# Altium schematic sheet ROBOSOM_V2R1.SchDoc
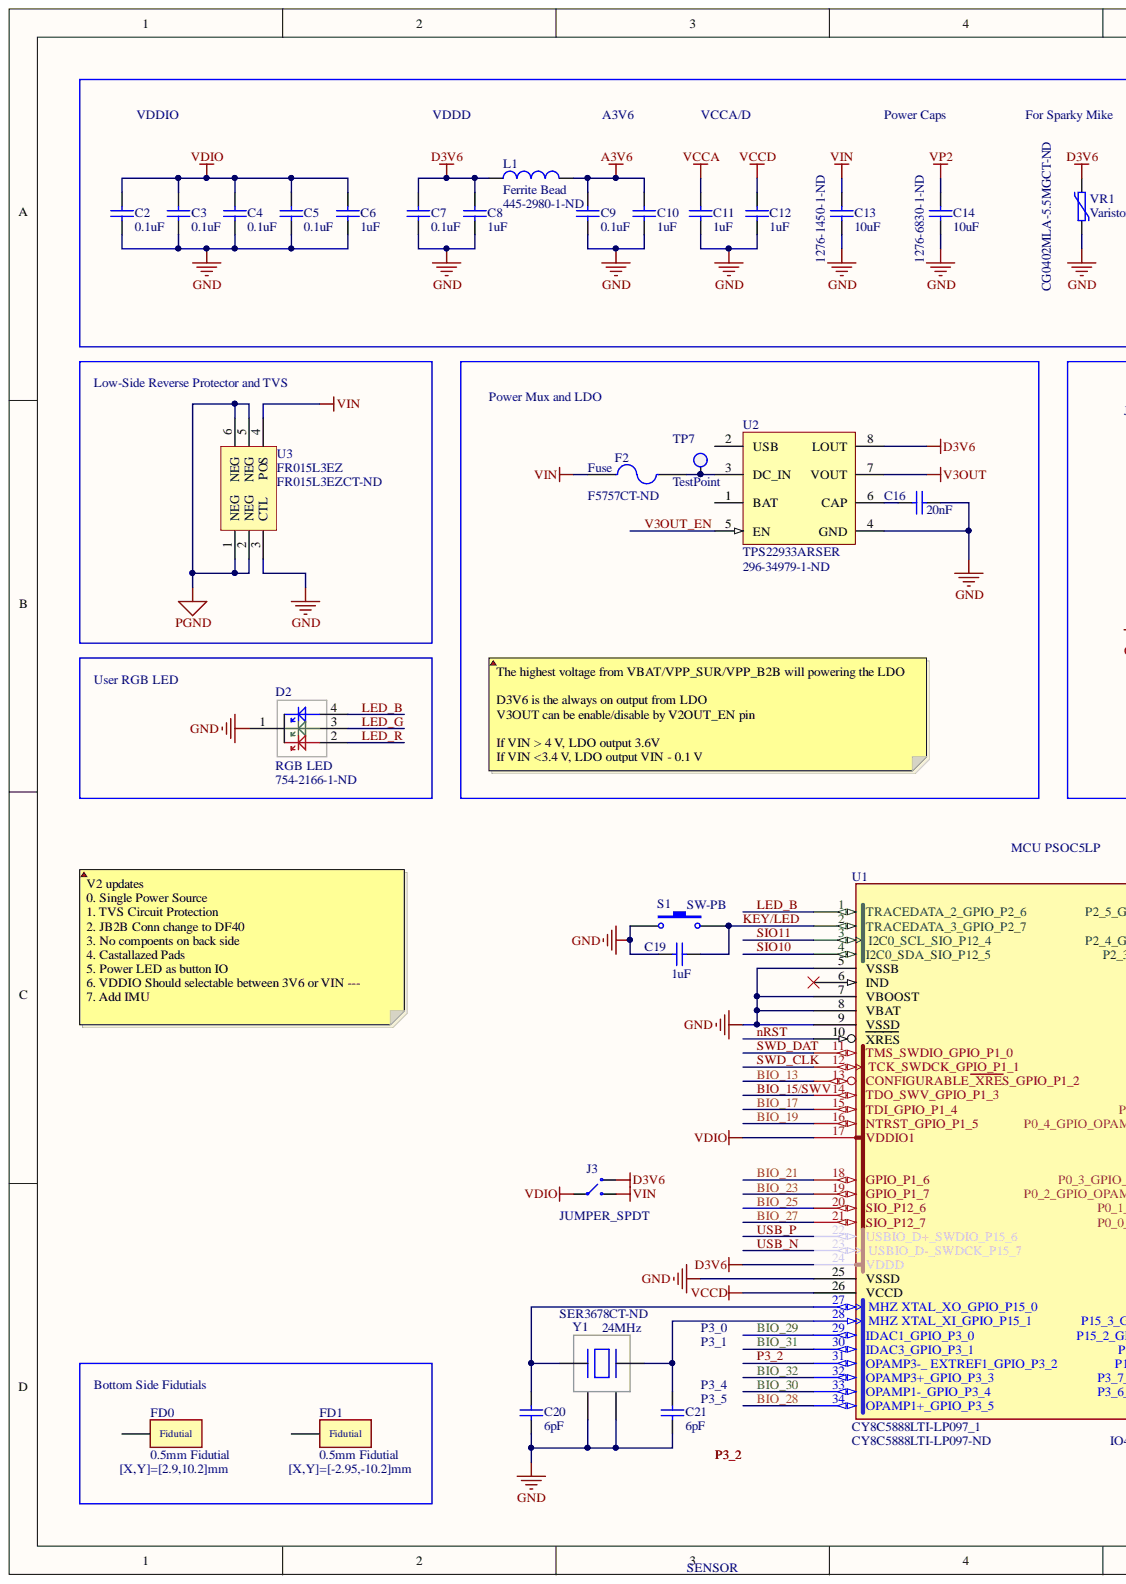
[diagram p0: ROBOSOM_V2R1.SchDoc, left]
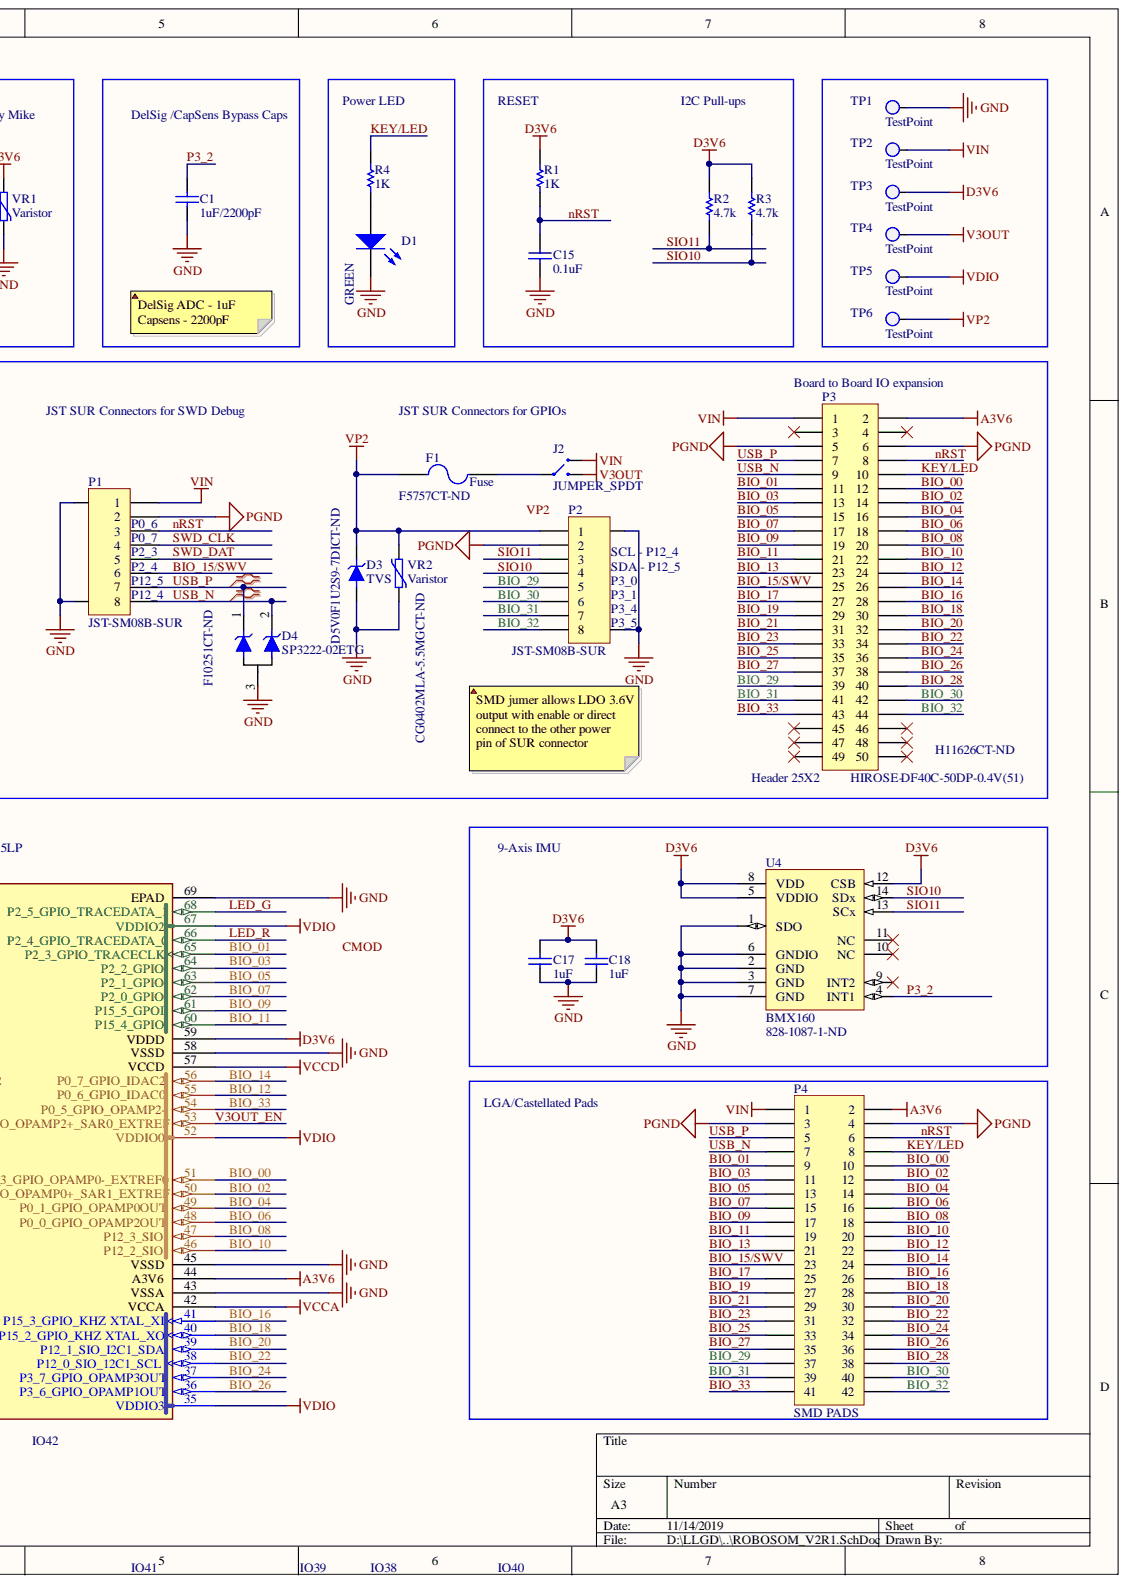
[diagram p1: ROBOSOM_V2R1.SchDoc, right]

## Parts
C1  Cap  value 1uF/2200pF  [C0201]
C2  value 0.1uF  [C0201]  (hidden comment: Cap)
C3  value 0.1uF  [C0201]  (hidden comment: Cap)
C4  value 0.1uF  [C0201]  (hidden comment: Cap)
C5  value 0.1uF  [C0201]  (hidden comment: Cap)
C6  value 1uF  [C0201]  (hidden comment: Cap)
C7  value 0.1uF  [C0201]  (hidden comment: Cap)
C8  value 1uF  [C0201]  (hidden comment: Cap)
C9  value 0.1uF  [C0201]  (hidden comment: Cap)
C10  value 1uF  [C0201]  (hidden comment: Cap)
C11  value 1uF  [C0201]  (hidden comment: Cap)
C12  value 1uF  [C0201]  (hidden comment: Cap)
C13  value 10uF  Capacitance: 10uF  Supplier Part Number 1: 1276-1450-1-ND  [C0402]  (hidden comment: Cap)
C14  Cap  value 10uF  Capacitance: 10uF  Supplier Part Number 2: 1276-6830-1-ND  [C0402]
C15  value 0.1uF  [C0201]  (hidden comment: Cap)
C16  value 20nF  [C0201]  (hidden comment: Cap)
C17  value 1uF  [C0201]  (hidden comment: Cap)
C18  value 1uF  [C0201]  (hidden comment: Cap)
C19  value 1uF  [C0201]  (hidden comment: Cap)
C20  value 6pF  [C0201]  (hidden comment: Cap)
C21  value 6pF  [C0201]  (hidden comment: Cap)
D1  GREEN  [D0402]
D2  RGB LED  Supplier Part Number 1: 754-2166-1-ND  [LED_RGB_0606_APTF1616SEJ3ZGGVBDC]
D3  TVS  Supplier Part Number 1: D5V0F1U2S9-7DICT-ND  [SOD-923]
D4  SP3222-02ETG  Supplier Part Number 1: F10251CT-ND  [UFDFN3-SP3222-02ETG]
F1  Fuse  Supplier Part Number 1: F5757CT-ND  [R0402]
F2  Fuse  Supplier Part Number 1: F5757CT-ND  [R0402]
FD0  0.5mm Fidutial  Location: [X,Y]=[2.9,10.2]mm  [Fiducial_0.5MM - S]
FD1  0.5mm Fidutial  Location: [X,Y]=[-2.95,-10.2]mm  [Fiducial_0.5MM - S]
J2  JUMPER_SPDT  [JUMPER_SPDT_0402_MINI_EN]
J3  JUMPER_SPDT  [JUMPER_SPDT_0402_MINI_EN]
L1  Ferrite Bead  [R0402]
P1  JST-SM08B-SUR  [SM08B-SURS-TF]
P2  JST-SM08B-SUR  [SM08B-SURS-TF]
P3  Header 25X2  Supplier Part Number 1: H11626CT-ND  [CON-HIROSE-DF40C-50DP-0.4V(51)]
P4  SMD PADS  [PSOC5_SOM_V2_SMD]
R1  value 1K  [R0201]  (hidden comment: Res1)
R2  value 4.7k  [R0201]  (hidden comment: Res1)
R3  value 4.7k  [R0201]  (hidden comment: Res1)
R4  value 1K  [R0201]  (hidden comment: Res1)
S1  SW-PB  [SW-PB-EVPBB]
TP1  TestPoint  [TP_0.75MM_RECT_SMD]
TP2  TestPoint  [TP_0.75MM_CIRL_SMD]
TP3  TestPoint  [TP_0.75MM_CIRL_SMD]
TP4  TestPoint  [TP_0.75MM_CIRL_SMD]
TP5  TestPoint  [TP_0.75MM_CIRL_SMD]
TP6  TestPoint  [TP_0.75MM_CIRL_SMD]
TP7  TestPoint  [TP_0.5mm_SMD]
U1  CY8C5888LTI-LP097_1  Supplier Part Number 1: CY8C5888LTI-LP097-ND  [QFN40P800X800X100-69N-PSOC5LP]
U2  TPS22933ARSER  Supplier Part Number 1: 296-34979-1-ND  [UFQFN-8-TPS22933]
U3  FR015L3EZ  Supplier Part Number 1: FR015L3EZCT-ND  [WDFN6-FR015L3EZ]
U4  BMX160  Supplier Part Number 1: 828-1087-1-ND  [VFLGA14-BMX160]
VR1  Varistor  Supplier Part Number 1: CG0402MLA-5.5MGCT-ND  [R0201]
VR2  Varistor  Supplier Part Number 1: CG0402MLA-5.5MGCT-ND  [R0201]
Y1  24MHz  Supplier Part Number 1: SER3678CT-ND  [CY-EPSON-FA-128-S]

## Nets
A3V6: C9.1 C10.1 L1.2 P3.2 P4.2 U1.44(A3V6)
D3V6: C7.1 C8.1 C17.2 C18.2 J3.1 L1.1 R1.1 R2.1 R3.1 TP3.1 U1.24(VDDD) U1.59(VDDD) U2.8(LOUT) U4.5(VDDIO) U4.8(VDD) U4.12(CSB) VR1.2
GND: C1.1 C2.2 C3.2 C4.2 C5.2 C6.2 C7.2 C8.2 C9.2 C10.2 C11.2 C12.2 C13.2 C14.2 C15.2 C16.2 C17.1 C18.1 C19.1 C20.2 C21.2 D1.2 D2.1 D3.A D4.3 P1.0 P2.0 S1.2 TP1.1 U1.5(VSSB) U1.7(VBOOST) U1.8(VBAT) U1.9(VSSD) U1.25(VSSD) U1.43(VSSA) U1.45(VSSD) U1.58(VSSD) U1.69(EPAD) U2.4(GND) U3.3(CTL) U4.1(SDO) U4.2(GND) U4.3(GND) U4.6(GNDIO) U4.7(GND) VR1.1 VR2.1 Y1.2 Y1.4
PGND: P1.2 P2.2 P3.5 P3.6 P4.3 P4.4 U3.1(NEG) U3.2(NEG) U3.5(NEG) U3.6(NEG)
V3OUT: J2.3 TP4.1 U2.7(VOUT)
VCCA: C11.1 U1.42(VCCA)
VCCD: C12.1 U1.26(VCCD) U1.57(VCCD)
VDIO: C2.1 C3.1 C4.1 C5.1 C6.1 J3.2 TP5.1 U1.17(VDDIO1) U1.35(VDDIO3) U1.52(VDDIO0) U1.67(VDDIO2)
VIN: C13.1 F2.2 J2.1 J3.3 P1.1 P3.1 P4.1 TP2.1 U3.4(POS)
BIO_00: P3.12 P4.10 U1.51(P0_3_GPIO_OPAMP0-_EXTREF0)
BIO_01: P3.11 P4.9 U1.65(P2_3_GPIO_TRACECLK)
BIO_02: P3.14 P4.12 U1.50(P0_2_GPIO_OPAMP0+_SAR1_EXTREF)
BIO_03: P3.13 P4.11 U1.64(P2_2_GPIO)
BIO_04: P3.16 P4.14 U1.49(P0_1_GPIO_OPAMP0OUT)
BIO_05: P3.15 P4.13 U1.63(P2_1_GPIO)
BIO_06: P3.18 P4.16 U1.48(P0_0_GPIO_OPAMP2OUT)
BIO_07: P3.17 P4.15 U1.62(P2_0_GPIO)
BIO_08: P3.20 P4.18 U1.47(P12_3_SIO)
BIO_09: P3.19 P4.17 U1.61(P15_5_GPOI)
BIO_10: P3.22 P4.20 U1.46(P12_2_SIO)
BIO_11: P3.21 P4.19 U1.60(P15_4_GPIO)
BIO_12: P3.24 P4.22 U1.55(P0_6_GPIO_IDAC0)
BIO_13: P3.23 P4.21 U1.13(CONFIGURABLE_XRES_GPIO_P1_2)
BIO_14: P3.26 P4.24 U1.56(P0_7_GPIO_IDAC2)
BIO_15/SWV: P1.6 P3.25 P4.23 U1.14(TDO_SWV_GPIO_P1_3)
BIO_16: P3.28 P4.26 U1.41(P15_3_GPIO_KHZ_XTAL_XI)
BIO_17: P3.27 P4.25 U1.15(TDI_GPIO_P1_4)
BIO_18: P3.30 P4.28 U1.40(P15_2_GPIO_KHZ_XTAL_XO)
BIO_19: P3.29 P4.27 U1.16(NTRST_GPIO_P1_5)
BIO_20: P3.32 P4.30 U1.39(P12_1_SIO_I2C1_SDA)
BIO_21: P3.31 P4.29 U1.18(GPIO_P1_6)
BIO_22: P3.34 P4.32 U1.38(P12_0_SIO_12C1_SCL)
BIO_23: P3.33 P4.31 U1.19(GPIO_P1_7)
BIO_24: P3.36 P4.34 U1.37(P3_7_GPIO_OPAMP3OUT)
BIO_25: P3.35 P4.33 U1.20(SIO_P12_6)
BIO_26: P3.38 P4.36 U1.36(P3_6_GPIO_OPAMP1OUT)
BIO_27: P3.37 P4.35 U1.21(SIO_P12_7)
BIO_28: P3.40 P4.38 U1.34(OPAMP1+_GPIO_P3_5)
BIO_29: P2.5 P3.39 P4.37 U1.29(IDAC1_GPIO_P3_0)
BIO_30: P2.6 P3.42 P4.40 U1.33(OPAMP1-_GPIO_P3_4)
BIO_31: P2.7 P3.41 P4.39 U1.30(IDAC3_GPIO_P3_1)
BIO_32: P2.8 P3.44 P4.42 U1.32(OPAMP3+_GPIO_P3_3)
BIO_33: P3.43 P4.41 U1.54(P0_5_GPIO_OPAMP2-)
KEY/LED: C19.2 P3.10 P4.8 R4.1 S1.1 U1.2(TRACEDATA_3_GPIO_P2_7)
LED_B: D2.4 U1.1(TRACEDATA_2_GPIO_P2_6)
LED_G: D2.3 U1.68(P2_5_GPIO_TRACEDATA_1)
LED_R: D2.2 U1.66(P2_4_GPIO_TRACEDATA_0)
nRST: C15.1 P1.3 P3.8 P4.6 R1.2 U1.10(XRES)
P3_2: C1.2 U1.31(OPAMP3-__EXTREF1_GPIO_P3_2) U4.4(INT1)
SIO10: P2.4 R3.2 U1.4(I2C0_SDA_SIO_P12_5) U4.14(SDx)
SIO11: P2.3 R2.2 U1.3(I2C0_SCL_SIO_P12_4) U4.13(SCx)
SWD_CLK: P1.4 U1.12(TCK_SWDCK_GPIO_P1_1)
SWD_DAT: P1.5 U1.11(TMS_SWDIO_GPIO_P1_0)
USB_N: D4.2 P1.8 P3.9 P4.7 U1.23(USBIO_D-_SWDCK_P15_7)
USB_P: D4.1 P1.7 P3.7 P4.5 U1.22(USBIO_D+_SWDIO_P15_6)
V3OUT_EN: U1.53(P0_4_GPIO_OPAMP2+_SAR0_EXTREF) U2.5(EN)
VP2: C14.1 D3.C F1.2 P2.1 TP6.1 VR2.2
NetC16_1: C16.1 U2.6(CAP)
NetC20_1: C20.1 U1.27(MHZ_XTAL_XO_GPIO_P15_0) Y1.3
NetC21_1: C21.1 U1.28(MHZ_XTAL_XI_GPIO_P15_1) Y1.1
NetD1_1: D1.1 R4.2
NetF1_1: F1.1 J2.2
NetF2_1: F2.1 TP7.1 U2.3(DC_IN)
CMOD:

Not connected: FD0 0; FD1 0; P3 3 4 45 46 47 48 49 50; U1 6(IND); U2 1(BAT) 2(USB); U4 9(INT2) 10(NC) 11(NC)

## Library data of the parts
C1-C12 C15-C21 = Cap: Capacitor; Component Kind: Standard; LatestRevisionDate: 17-Jul-2002; LatestRevisionNote: Re-released for DXP Platform.; Library Name: Miscellaneous Devices.IntLib; PackageDescription: Radial Cap, Thru-Hole; 2 Leads; 0.3 in Pin Spacing; PackageReference: RAD-0.3; Published: 8-Jun-2000; Publisher: Altium Limited; Signal Integrity: Cap; Simulation: CAP
  C20 C21: DigiKeyPN: 490-3109-1-ND
C13 C14 = Cap: Capacitor; Manufacturer: Samsung; Manufacturer Part Number: CL05A106MP5NUNC; Dielectric: X5R; Tolerance: 20%; Voltage Rating: 10V; Case/Package: 0402; Case Code (Imperial): 0402; Case Code (Metric): 1005; Component Kind: Standard; ComponentLink1Description: https://media.digikey.com/pdf/Data%20Sheets/Samsung%20PDFs/CL_Series_MLCC_ds.pdf?src-supplier=Digi-Key; ComponentLink1URL: https://media.digikey.com/pdf/Data%20Sheets/Samsung%20PDFs/CL_Series_MLCC_ds.pdf?src-supplier=Digi-Key; ComponentLink2Description: https://www.verical.com/server-webapp/html/getDatasheet?partID=745310&src-supplier=Verical; ComponentLink2URL: https://www.verical.com/server-webapp/html/getDatasheet?partID=745310&src-supplier=Verical; Contact Plating: Tin; Depth: 0.5mm; LatestRevisionDate: 17-Jul-2002; LatestRevisionNote: Re-released for DXP Platform.; Lead Free: Lead Free; Length: 1mm; Library Name: Miscellaneous Devices.IntLib; Max Operating Temperature: 85°C; Min Operating Temperature: -55°C; Mount: Surface Mount; PackageDescription: Radial Cap, Thru-Hole; 2 Leads; 0.3 in Pin Spacing; Package Quantity: 1; PackageReference: RAD-0.3; Packaging: Tape and Reel; Pricing 1: 1 = 0.50000, 10 = 0.34500, 100 = 0.20700, 500 = 0.15526, 1000 = 0.13110, 2500 = 0.12420, 5000 = 0.11730 (USD); Published: 8-Jun-2000; Publisher: Altium Limited; Radiation Hardening: No; RoHS: Yes; Signal Integrity: Cap; Simulation: CAP; Stock 1: 13886; Supplier 1: Digi-Key; Termination: Wraparound; Thickness: 0.028inch; Width: 0.02inch
  C14: Pricing 2: 1 = 0.45000, 10 = 0.31100, 100 = 0.18630, 500 = 0.13972, 1000 = 0.11799, 2500 = 0.11178 (USD); Stock 2: 1465552; Supplier 2: Digi-Key
D1 = LED0: Typical INFRARED GaAs LED; Component Kind: Standard; LatestRevisionDate: 08-Jul-2005; LatestRevisionNote: Mech Layer 1 removed.; Library Name: Miscellaneous Devices.IntLib; PackageDescription: LED; 2 Leads; PackageReference: LED-0; Published: 8-Jun-2000; Publisher: Altium Limited; Simulation: LED0
D2 = LED_RGB_0606_APTF1616SEJ3ZGGVBDC: LED RGB DIFFUSED 0606 SMD; Component Kind: Standard; Library Name: LLLib_RCLQD.SchLib; Supplier 1: Digi-Key
D3 = TVS_5.5V: LOW CAPACITANCE UNIDIRECTIONAL TVS DIODE; Component Kind: Standard; Library Name: LLLib_RCLQD.SchLib; Supplier 1: Digi-Key
D4 = TVS_SP3222-02ETG: TVS DIODE 3.3V 10V SOD883; Component Kind: Standard; Library Name: LLLib_RCLQD.SchLib; Supplier 1: Digi-Key
F1 F2 = Fuse 2: Manufacturer: Littelfuse; Manufacturer Part Number: 0402L020SLKR; Approvals: UL; Hold Current: 200mA; Max Current Rating: 40A; Max Voltage Rating (DC): 6V; Power Dissipation: 500mW; Resistance: 1.25R; Time to Trip: 1s; Trip Current: 500mA; Case/Package: 0402; Case Code (Imperial): 0402; Case Code (Metric): 1005; Component Kind: Standard; ComponentLink1Description: http://www.littelfuse.com/~/media/electronics/datasheets/resettable_ptcs/littelfuse_ptc_lorho_datasheet.pdf.pdf?src-supplier=Digi-Key; ComponentLink1URL: http://www.littelfuse.com/~/media/electronics/datasheets/resettable_ptcs/littelfuse_ptc_lorho_datasheet.pdf.pdf?src-supplier=Digi-Key; Description: Fuse; DigiKeyPN: MF-FSMF050X-2CT-ND; LatestRevisionDate: 17-Jul-2002; LatestRevisionNote: Re-released for DXP Platform.; Library Name: Miscellaneous Devices.IntLib; Max Operating Temperature: 85°C; Min Operating Temperature: -40°C; Operating Supply Voltage: 6V; PackageDescription: Thru-Hole; 2 Leads; Package Quantity: 10000; PackageReference: PIN-W2/E2.8; Packaging: Tape and Reel; Pricing 1: 1 = 2.87000, 5 = 2.73600, 10 = 2.59900, 50 = 2.09740, 100 = 1.77820, 250 = 1.73260, 500 = 1.45904, 1000 = 1.29946, 5000 = 1.13988 (USD); Published: 8-Jun-2000; Publisher: Altium Limited; RoHS: Yes; Simulation: FUSE; Stock 1: 22870; Supplier 1: Digi-Key; Termination: SMD/SMT; Voltage Rating (DC): 6V
FD0 FD1 = Fidutial: Fidutial Marker; Component Kind: Standard; Library Name: LLLib_Mechanical.SchLib
J2 J3 = JUMPER_SPDT_0402: Component Kind: Standard; Library Name: LLLib_Connectors.SchLib
L1 = Inductor: Code_IEC: 1005; Value: 445-2980-1-ND; Code_JEITA: 0402; Component Kind: Standard; Description: Inductor; DigiKeyPN: 445-2980-1-ND; LatestRevisionDate: 29-May-2009; LatestRevisionNote: IPC-7351 Footprint Added.; Library Name: Miscellaneous Devices.IntLib; PackageDescription: Chip Inductor; PackageReference: 0402-A; Published: 8-Jun-2000; Publisher: Altium Limited; Signal Integrity: Inductor; Simulation: INDUCTOR
P1 P2 = CON-JST-SUR-SM08B: JST SUR Connector 0.8mm Pitch; Component Kind: Standard; DigiKeyPN: 455-2040-1-ND; Library Name: LLLib_Connectors.SchLib
P3 = Header 25X2: Header, 25-Pin, Dual row; Manufacturer: Hirose; Manufacturer Part Number: DF40C-50DP-0.4V(51); Connector Type: Plug; Current Rating: 300mA; Gender: Male; Mated Stacking Heights: 4mm; Number of Contacts: 50; Pitch: 0.4mm; Stack Height: 1.5mm; Voltage Rating: 30V; Component Kind: Standard; ComponentLink1Description: https://datasheet.ciiva.com/46408/1824826-46408906.pdf?src-supplier=Element14; ComponentLink1URL: https://datasheet.ciiva.com/46408/1824826-46408906.pdf?src-supplier=Element14; Contact Material: Bronze; Contact Plating: Gold; Housing Material: Polymer; LatestRevisionDate: 17-Jul-2002; LatestRevisionNote: Re-released for DXP Platform.; Lead Free: Lead Free; Library Name: Miscellaneous Connectors.IntLib; Mount: Surface Mount; Number of Positions: 50; Number of Rows: 2; Package Quantity: 1; Packaging: Tape and Reel; Pricing 1: 1=1.12000, 10=0.98200, 25=0.92400, 50=0.88560, 100=0.84700, 250=0.77000, 500=0.69300, 1000=0.61600 (USD); Publisher: Altium Limited; REACH SVHC: No SVHC; RoHS: Yes; Signal Integrity: Connector; Stock 1: 21693; Supplier 1: Digi-Key; Termination: SMD/SMT
P4 = PSOC5_SOM_V2_SMD: Component Kind: Standard; Library Name: LLLib_Module.SchLib
R1-R4 = Res1: Resistor; Component Kind: Standard; LatestRevisionDate: 17-Jul-2002; LatestRevisionNote: Re-released for DXP Platform.; Library Name: Miscellaneous Devices.IntLib; PackageDescription: Axial Device, Thru-Hole; 2 Leads; 0.3 in Pin Spacing; PackageReference: AXIAL-0.3; Published: 8-Jun-2000; Publisher: Altium Limited; Signal Integrity: Res; Simulation: RESISTOR
S1 = SW-PB: Switch; Component Kind: Standard; DigiKeyPN: P19889CT-ND; LatestRevisionDate: 17-Jul-2002; LatestRevisionNote: Re-released for DXP Platform.; Library Name: Miscellaneous Devices.IntLib; PackageDescription: Switch; 2 Leads; PackageReference: SPST-2; Published: 24-Mar-1999; Publisher: Altium Limited
TP1-TP7 = TEST_POINT: Component Kind: Standard; Description: TestPoint; Library Name: LLLib_Connectors.SchLib
U1 = CY8C5888LTI-LP097_1: Manufacturer Name: CYPRESS; Part Number: CY8C5868LTI-LP036; Component Kind: Standard; Library Name: LLLib_MCU.SchLib; Supplier 1: Digi-Key
U2 = PMIC-OR-TPS22933: Small, Triple-Input Power Multiplexer with Auto-Select and Low Drop-out Voltage Regulator, 2.5 to 12 V, -40 to 85 degC, 8-pin UQFN (RSE), Green (RoHS & no Sb/Br); Manufacturer: Texas Instruments; Current - Output 2: 50mA; IN1, IN2 Input Voltage(Max)(V): 12; IN1, IN2 Input Voltage(Min)(V): 2.5; IN1 Output Current(Max)(A): 0.05; IN1 rDS(on)(Typ)(mOhm): 2600; IN2 rDS(on)(Typ)(Ohms): 2.6; Max Input Voltage: 12V; Max Supply Current: 15uA; Min Input Voltage: 2.5V; Number of Inputs: 3; Number of Outputs: 2; On-State Resistance: 6R; SubFamily: Power Multiplexer (MUX); Turn-Off Delay Time: 32us; Turn-On Delay Time: 65us; Code_JEDEC: MO-288; Component Kind: Standard; ComponentLink1Description: Datasheet; ComponentLink1URL: http://www.ti.com/general/docs/lit/getliterature.tsp?genericPartNumber=TPS22933A&fileType=pdf; ComponentLink2Description: http://www.ti.com/lit/ds/symlink/tps22933.pdf?src-supplier=Digi-Key; ComponentLink2URL: http://www.ti.com/lit/ds/symlink/tps22933.pdf?src-supplier=Digi-Key; ComponentLink3Description: http://www.ti.com/lit/gpn/tps22933?src-supplier=Mouser; ComponentLink3URL: http://www.ti.com/lit/gpn/tps22933?src-supplier=Mouser; ComponentLink4Description: http://www.ti.com/general/docs/lit/getliterature.tsp?genericPartNumber=TPS22933A&&fileType=pdf&src-supplier=Avnet; ComponentLink4URL: http://www.ti.com/general/docs/lit/getliterature.tsp?genericPartNumber=TPS22933A&&fileType=pdf&src-supplier=Avnet; DatasheetVersion: SLVSB34; Eco-Plan: Green (RoHS & no Sb/Br); IN2 Output Current(Max)(A): 0.05; Lead Free: Lead Free; Library Name: LLLib_Power.SchLib; Manufacturer Part Number: TPS22933ARSER; Max Operating Temperature: 85°C; Max Output Current: 50mA; Min Operating Temperature: -40°C; Mounting Technology: Surface Mount; Number of Pins: 8; Operating Supply Voltage: 12V; Output Current: 50mA; PackageDescription: 8-Pin Plastic Quad Flatpack No-Lead, 1.5 x 1.5 mm Body, 0.5 mm Pitch; Package Quantity: 1; PackageReference: RSE0008A; PackageVersion: revD, Jan-2011; Packaging: Tape and Reel; PartNumber: TPS22933ARSER; Pricing 1: 1 = 0.87000, 10 = 0.77500, 100 = 0.60410, 500 = 0.49904, 1000 = 0.39398 (USD); RoHS: Yes; Stock 1: 5196; Supplier 1: Digi-Key; Type: General Purpose
U3 = FR015L3EZ: LOW SIDE REV BIAS POLAR PROTECT; Component Kind: Standard; Library Name: LLLib_IC.SchLib; Supplier 1: Digi-Key
U4 = BMX160: IMU ACCEL/GYRO/MAG; Component Kind: Standard; Library Name: LLLib_IC.SchLib; Supplier 1: Digi-Key
VR1 VR2 = Varistor_DC5.5V: SMD multilayer varistors (MLVs); Manufacturer: Bourns; Manufacturer Part Number: CG0402MLA-5.5MG; Capacitance: 270pF; Clamping Current: 1A; Clamping Voltage: 19V; Current Rating: 20A; Energy: 0.05J; Max Varistor Voltage: 9.6V; Max Voltage Rating (AC): 4V; Max Voltage Rating (DC): 5.5V; Min Varistor Voltage: 6.4V; Number of Channels: 1; Tolerance: 20%; Case/Package: 0402; Case Code (Imperial): 0402; Case Code (Metric): 1005; Component Kind: Standard; ComponentLink1Description: http://www.bourns.com/data/global/pdfs/MLA.pdf?src-supplier=Avnet; ComponentLink1URL: http://www.bourns.com/data/global/pdfs/MLA.pdf?src-supplier=Avnet; ComponentLink2Description: http://www.bourns.com/data/global/pdfs/MLA.pdf?src-supplier=Avnet+Europe; ComponentLink2URL: http://www.bourns.com/data/global/pdfs/MLA.pdf?src-supplier=Avnet+Europe; ComponentLink3Description: https://www.bourns.com/docs/Product-Datasheets/MLA.pdf?src-supplier=Digi-Key; ComponentLink3URL: https://www.bourns.com/docs/Product-Datasheets/MLA.pdf?src-supplier=Digi-Key; Contact Plating: Nickel; Depth: 0.5mm; Length: 1mm; Library Name: LLLib_RCLQD.SchLib; Max Operating Temperature: 125°C; Max Surge Current: 20A; Min Operating Temperature: -55°C; Mount: Surface Mount; Number of Circuits: 1; Operating Supply Voltage: 5.5V; Package Quantity: 1; Packaging: Tape and Reel; Pricing 1: 1 = 0.20000, 10 = 0.18400, 25 = 0.16720, 100 = 0.12050, 250 = 0.07096, 500 = 0.05892, 1000 = 0.04017, 2500 = 0.03414, 5000 = 0.03080 (USD); REACH SVHC: No SVHC; RoHS: Yes; Stock 1: 25188; Supplier 1: Digi-Key; Termination: SMD/SMT; Varistor Voltage: 9.6V; Voltage: 5.5V; Voltage Rating (AC): 4V; Voltage Rating (DC): 5.5V; Voltage Tolerance: 20%; Width: 0.5mm
Y1 = CY_EPSON_FA-128: CRYSTAL 24MHZ SMD; Manufacturer: Epson; Manufacturer Part Number: FA-12824.0000MF10Z-W3; ESR (Equivalent Series Resistance): 80R; Frequency Stability: 10ppm; Load Capacitance: 12pF; Case/Package: SMD/SMT; Component Kind: Standard; ComponentLink1Description: http://www.mouser.com/ds/2/137/A-128_en-931416.pdf?src-supplier=Mouser; ComponentLink1URL: http://www.mouser.com/ds/2/137/A-128_en-931416.pdf?src-supplier=Mouser; Frequency: 24MHz; Frequency Tolerance: 10ppm; Height: 0.02inch; Lead Free: Lead Free; Length: 0.079inch; Library Name: LLLib_RCLQD.SchLib; Max Operating Temperature: 75°C; Min Operating Temperature: -20°C; Mount: Surface Mount; Number of Pins: 4; Package Quantity: 250; Packaging: Tape and Reel; Pricing 1: 1 = 0.59000, 10 = 0.49500, 50 = 0.44560, 100 = 0.39600 (USD); Radiation Hardening: No; RoHS: Yes; Stock 1: 9441; Supplier 1: Digi-Key; Termination: SMD/SMT; Tolerance: 10ppm; Width: 0.063inch
